# Altium schematic sheet RF.SchDoc
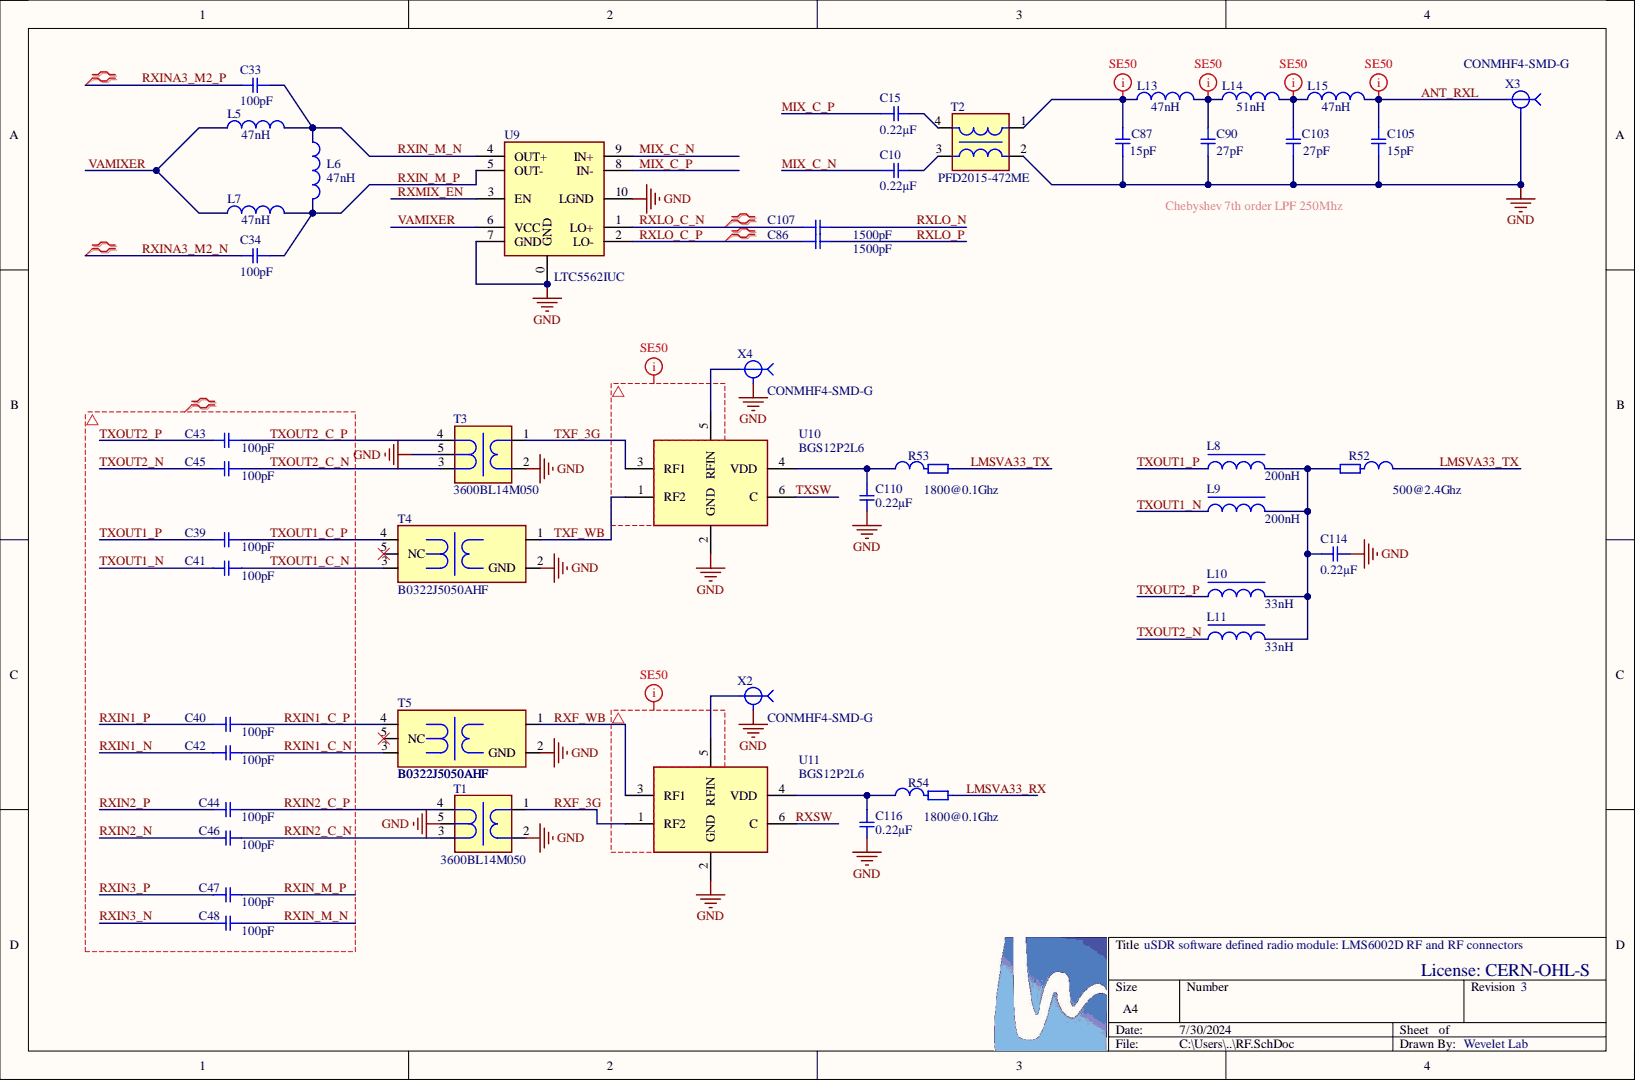
[diagram p0: RF.SchDoc]

## Parts
C10  value 0.22µF  [SMD-0201C]  (hidden comment: GRM033C80J224ME90D)
C15  value 0.22µF  [SMD-0201C]  (hidden comment: GRM033C80J224ME90D)
C33  value 100pF  [SMD-0201C]  (hidden comment: GRM0335C1H101JA01D)
C34  value 100pF  [SMD-0201C]  (hidden comment: GRM0335C1H101JA01D)
C39  value 100pF  [SMD-0201C]  (hidden comment: GRM0335C1H101JA01D)
C40  value 100pF  [SMD-0201C]  (hidden comment: GRM0335C1H101JA01D)
C41  value 100pF  [SMD-0201C]  (hidden comment: GRM0335C1H101JA01D)
C42  value 100pF  [SMD-0201C]  (hidden comment: GRM0335C1H101JA01D)
C43  value 100pF  [SMD-0201C]  (hidden comment: GRM0335C1H101JA01D)
C44  value 100pF  [SMD-0201C]  (hidden comment: GRM0335C1H101JA01D)
C45  value 100pF  [SMD-0201C]  (hidden comment: GRM0335C1H101JA01D)
C46  value 100pF  [SMD-0201C]  (hidden comment: GRM0335C1H101JA01D)
C47  value 100pF  [SMD-0201C]  (hidden comment: GRM0335C1H101JA01D)
C48  value 100pF  [SMD-0201C]  (hidden comment: GRM0335C1H101JA01D)
C86  value 1500pF  [SMD-0201C]  (hidden comment: GRM033R71E152KA01D)
C87  value 15pF  [SMD-0201C]  (hidden comment: GRM0335C1H150FA01D)
C90  value 27pF  [SMD-0201C]  (hidden comment: GRM0335C1H270FA01D)
C103  value 27pF  [SMD-0201C]  (hidden comment: GRM0335C1H270FA01D)
C105  value 15pF  [SMD-0201C]  (hidden comment: GRM0335C1H150FA01D)
C107  value 1500pF  [SMD-0201C]  (hidden comment: GRM033R71E152KA01D)
C110  value 0.22µF  [SMD-0201C]  (hidden comment: GRM033C80J224ME90D)
C114  value 0.22µF  [SMD-0201C]  (hidden comment: GRM033C80J224ME90D)
C116  value 0.22µF  [SMD-0201C]  (hidden comment: GRM033C80J224ME90D)
L5  value 47nH  [SMD-0201-RES]  (hidden comment: MLG0603P47NHT000)
L6  value 47nH  [SMD-0201-RES]  (hidden comment: MLG0603P47NHT000)
L7  value 47nH  [SMD-0201-RES]  (hidden comment: MLG0603P47NHT000)
L8  value 200nH  [0201AF]  (hidden comment: 0201AF-201XKR)
L9  value 200nH  [0201AF]  (hidden comment: 0201AF-201XKR)
L10  value 33nH  [0201AF]  (hidden comment: 0201AF-330XKR)
L11  value 33nH  [0201AF]  (hidden comment: 0201AF-330XKR)
L13  value 47nH  [SMD-0201-RES]  (hidden comment: MLG0603P47NHT000)
L14  value 51nH  [SMD-0201-RES]  (hidden comment: MLG0603P51NHT000)
L15  value 47nH  [SMD-0201-RES]  (hidden comment: MLG0603P47NHT000)
R52  value 500@2.4Ghz  [SMD-0201-RES]  (hidden comment: BLF03RD501GNE)
R53  value 1800@0.1Ghz  [SMD-0201-RES]  (hidden comment: BLM03HD182FN1)
R54  value 1800@0.1Ghz  [SMD-0201-RES]  (hidden comment: BLM03HD182FN1)
T1  3600BL14M050  [Balun 1.6x0.8x0.6mm]
T2  PFD2015-472ME  [PFD2015]
T3  3600BL14M050  [Balun 1.6x0.8x0.6mm]
T4  B0322J5050AHF  [BALUN 2.04x1.39x0.71]
T5  B0322J5050AHF  [BALUN 2.04x1.39x0.71]
U9  LTC5562IUC  [UC10(2x2)]
U10  BGS12P2L6  [TSLP-6-4]
U11  BGS12P2L6  [TSLP-6-4]
X2  CONMHF4-SMD-G  [MHF4]
X3  CONMHF4-SMD-G  [MHF4]
X4  CONMHF4-SMD-G  [MHF4]

## Nets
GND: C87.1 C90.1 C103.1 C105.1 C110.2 C114.1 C116.2 T1.2 T1.5 T2.2 T3.2 T3.5 T4.2(GND) T5.2(GND) U9.0(GND) U9.7(GND) U9.10(LGND) U10.2(GND) U11.2(GND) X2.2 X3.2 X4.2
ANT_RXL: C105.2 L15.2 X3.1
LMSVA33_RX: R54.2
LMSVA33_TX: R52.1 R53.2
MIX_C_N: C10.1 U9.9(IN+)
MIX_C_P: C15.1 U9.8(IN-)
RXF_3G: T1.1 U11.1(RF2)
RXF_WB: T5.1 U11.3(RF1)
RXIN1_C_N: C42.2 T5.3
RXIN1_C_P: C40.2 T5.4
RXIN1_N: C42.1
RXIN1_P: C40.1
RXIN2_C_N: C46.2 T1.3
RXIN2_C_P: C44.2 T1.4
RXIN2_N: C46.1
RXIN2_P: C44.1
RXIN3_N: C48.1
RXIN3_P: C47.1
RXIN_M_N: C33.1 C48.2 L5.1 L6.2 U9.4(OUT+)
RXIN_M_P: C34.1 C47.2 L6.1 L7.1 U9.5(OUT-)
RXINA3_M2_N: C34.2
RXINA3_M2_P: C33.2
RXLO_C_N: C107.1 U9.1(LO+)
RXLO_C_P: C86.1 U9.2(LO-)
RXLO_N: C107.2
RXLO_P: C86.2
RXMIX_EN: U9.3(EN)
RXSW: U11.6(C)
TXF_3G: T3.1 U10.3(RF1)
TXF_WB: T4.1 U10.1(RF2)
TXOUT1_C_N: C41.1 T4.3
TXOUT1_C_P: C39.1 T4.4
TXOUT1_N: C41.2 L9.2
TXOUT1_P: C39.2 L8.2
TXOUT2_C_N: C45.1 T3.3
TXOUT2_C_P: C43.1 T3.4
TXOUT2_N: C45.2 L11.2
TXOUT2_P: C43.2 L10.2
TXSW: U10.6(C)
VAMIXER: L5.2 L7.2 U9.6(VCC)
NetC10_2: C10.2 T2.3
NetC15_2: C15.2 T2.4
NetC87_2: C87.2 L13.1 T2.1
NetC90_2: C90.2 L13.2 L14.1
NetC103_2: C103.2 L14.2 L15.1
NetC110_1: C110.1 R53.1 U10.4(VDD)
NetC114_2: C114.2 L8.1 L9.1 L10.1 L11.1 R52.2
NetC116_1: C116.1 R54.1 U11.4(VDD)
NetU10_5: U10.5(RFIN) X4.1
NetU11_5: U11.5(RFIN) X2.1

Not connected: T4 5(NC); T5 5(NC)

## Library data of the parts
C10 C15 C110 C114 C116 = GRM033C80J224ME90D: CAP CER 0.22UF 6.3V X6S 0201; Manufacturer: Murata Electronics North America; @ qty: 0; Minimum Quantity: 1; Tolerance: ±20%; Unit Price (USD): 0.1; Applications: General Purpose; Component Kind: Standard; Datasheets: http://www.murata.com/~/media/webrenewal/support/library/catalog/products/capacitor/mlcc/c02e.pdf; Digi-Key Part Number: 490-9982-1-ND; Factory Stock: 0; Failure Rate: Surface Mount, MLCC; Features: -; Height - Seated (Max): 0.013" (0.33mm); Image: //media.digikey.com/Renders/Murata%20Renders/ZRB-SERIES-0402.jpg; Lead Spacing: -; Mounting Type: 0201 (0603 Metric); Operating Temperature: -55°C ~ 105°C; Package / Case: 0.024" L x 0.012" W (0.60mm x 0.30mm); Packaging: Cut Tape (CT); Part Number: GRM033C80J224ME90D; Part Status: Active; Quantity Available: 381529; Ratings: -; Series: GRM; Size / Dimension: -; Temperature Coefficient: X6S; Thickness (Max): -; Voltage: 6.3V; Library Name: USDR.IntLib
C33 C34 C39-C48 = GRM0335C1H101JA01D: CAP CER 100PF 50V NP0 0201; Manufacturer: Murata Electronics North America; @ qty: 0; Minimum Quantity: 1; Temperature Coefficient: C0G, NP0; Tolerance: ±5%; Unit Price (USD): 0.1; Applications: General Purpose; Component Kind: Standard; Datasheets: http://www.murata.com/~/media/webrenewal/support/library/catalog/products/capacitor/mlcc/c02e.pdf; Digi-Key Part Number: 490-6113-1-ND; Factory Stock: 0; Failure Rate: Surface Mount, MLCC; Features: -; Height - Seated (Max): -; Image: //media.digikey.com/Renders/Murata%20Renders/ZRB-SERIES-0402.jpg; Mounting Type: 0201 (0603 Metric); Operating Temperature: -55°C ~ 125°C; Package / Case: 0.024" L x 0.012" W (0.60mm x 0.30mm); Packaging: Cut Tape (CT); Part Number: GRM0335C1H101JA01D; Part Status: Active; Quantity Available: 19110; Ratings: -; Series: GRM; Size / Dimension: -; Thickness (Max): -; Voltage: 50V; Library Name: USDR.IntLib
C86 C107 = GRM033R71E152KA01D: CAP CER 1500PF 25V X7R 0201; Manufacturer: Murata Electronics North America; @ qty: 0; Minimum Quantity: 1; Tolerance: ±10%; Unit Price (USD): 0.1; Applications: General Purpose; Component Kind: Standard; Datasheets: http://www.murata.com/~/media/webrenewal/support/library/catalog/products/capacitor/mlcc/c02e.pdf; Digi-Key Part Number: 490-3185-1-ND; Factory Stock: 0; Failure Rate: Surface Mount, MLCC; Features: -; Height - Seated (Max): 0.013" (0.33mm); Image: //media.digikey.com/Renders/Murata%20Renders/ZRB-SERIES-0402.jpg; Lead Spacing: -; Mounting Type: 0201 (0603 Metric); Operating Temperature: -55°C ~ 125°C; Package / Case: 0.024" L x 0.012" W (0.60mm x 0.30mm); Packaging: Cut Tape (CT); Part Number: GRM033R71E152KA01D; Part Status: Active; Quantity Available: 60053; Ratings: -; Series: GRM; Size / Dimension: -; Temperature Coefficient: X7R; Thickness (Max): -; Voltage: 25V; Library Name: USDR.IntLib
C87 C105 = GRM0335C1H150FA01D: CAP CER 15PF 50V NP0 0201; Manufacturer: Murata Electronics North America; @ qty: 0; Minimum Quantity: 1; Temperature Coefficient: C0G, NP0; Tolerance: ±1%; Unit Price (USD): 0.1; Applications: General Purpose; Component Kind: Standard; Datasheets: http://www.murata.com/~/media/webrenewal/support/library/catalog/products/capacitor/mlcc/c02e.pdf; Digi-Key Part Number: 490-GRM0335C1H150FA01DTR-ND; Factory Stock: 0; Failure Rate: Surface Mount, MLCC; Features: -; Height - Seated (Max): -; Image: //media.digikey.com/Renders/Murata%20Renders/ZRB-SERIES-0402.jpg; Mounting Type: 0201 (0603 Metric); Operating Temperature: -55°C ~ 125°C; Package / Case: 0.024" L x 0.012" W (0.60mm x 0.30mm); Packaging: Cut Tape (CT); Part Number: GRM0335C1H150FA01D; Part Status: Active; Quantity Available: 29005; Ratings: -; Series: GRM; Size / Dimension: -; Thickness (Max): -; Voltage: 50V; Library Name: USDR.IntLib
C90 C103 = GRM0335C1H270FA01D: CAP CER 27PF 50V NP0 0201; Manufacturer: Murata Electronics North America; @ qty: 0; Minimum Quantity: 1; Temperature Coefficient: C0G, NP0; Tolerance: ±1%; Unit Price (USD): 0.1; Applications: General Purpose; Component Kind: Standard; Datasheets: http://www.murata.com/~/media/webrenewal/support/library/catalog/products/capacitor/mlcc/c02e.pdf; Digi-Key Part Number: 490-GRM0335C1H270FA01DTR-ND; Factory Stock: 0; Failure Rate: Surface Mount, MLCC; Features: -; Height - Seated (Max): -; Image: //media.digikey.com/Renders/Murata%20Renders/ZRB-SERIES-0402.jpg; Mounting Type: 0201 (0603 Metric); Operating Temperature: -55°C ~ 125°C; Package / Case: 0.024" L x 0.012" W (0.60mm x 0.30mm); Packaging: Cut Tape (CT); Part Number: GRM0335C1H270FA01D; Part Status: Active; Quantity Available: 29005; Ratings: -; Series: GRM; Size / Dimension: -; Thickness (Max): -; Voltage: 50V; Library Name: USDR.IntLib
L5-L7 L13 L15 = MLG0603P47NHT000: IND 47nH 0201; Manufacturer: TDK; Tolerance: ±3%; Component Kind: Standard; Link: https://product.tdk.com/system/files/dam/doc/product/inductor/inductor/smd/catalog/inductor_commercial_high-frequency_mlg0603p_en.pdf; Name: MLG0603P47NHT000; Library Name: USDR.IntLib
L8 L9 = 0201AF-201XKR: RF Choke 200nH 110mA 1.3Ohm DCR; Manufacturer: Coilcraft; Component Kind: Standard; Link: https://www.coilcraft.com/getmedia/29a2c174-dfd1-4d85-9887-6563ebac31ff/0201af.pdf; Name: 0201AF-201XKR; Library Name: USDR.IntLib
L10 L11 = 0201AF-330XKR: RF Choke 33nH 340mA 0.15Ohm DCR; Manufacturer: Coilcraft; Component Kind: Standard; Link: https://www.coilcraft.com/getmedia/29a2c174-dfd1-4d85-9887-6563ebac31ff/0201af.pdf; Name: 0201AF-330XKR; Library Name: USDR.IntLib
L14 = MLG0603P51NHT000: IND 51nH 0201; Manufacturer: TDK; Tolerance: ±3%; Component Kind: Standard; Link: https://product.tdk.com/system/files/dam/doc/product/inductor/inductor/smd/catalog/inductor_commercial_high-frequency_mlg0603p_en.pdf; Name: MLG0603P51NHT000; Library Name: USDR.IntLib
R52 = BLF03RD501GNE: BEAD 0.36Ohm/500mA 500@2.4Ghz; Manufacturer: Murata Electronics North America; Tolerance: ±40%; Component Kind: Standard; Link: https://product.tdk.com/system/files/dam/doc/product/inductor/inductor/smd/catalog/inductor_commercial_high-frequency_mlg0603p_en.pdf; Name: BLF03RD501GNE; Library Name: USDR.IntLib
R53 R54 = BLM03HD182FN1: BEAD 3.8Ohm/100mA 1800@0.1Ghz/3000@1.0Ghz; Manufacturer: TDKMurata Electronics North America; Tolerance: ±3%; Component Kind: Standard; Link: https://product.tdk.com/system/files/dam/doc/product/inductor/inductor/smd/catalog/inductor_commercial_high-frequency_mlg0603p_en.pdf; Name: BLM03HD182FN1; Library Name: USDR.IntLib
T1 T3 = 3600BL14M050: Balun bal-unbal; Manufacturer: Johanson Technology, Inc.; Name: Balun 50/50 3-4 GHz; Component Kind: Standard; Link: https://octopart.com/3600bl14m050t-johanson-11760610; Value: 3600BL14M050; Library Name: USDR.IntLib
T2 = PFD2015-472ME: Balun bal-unbal; Manufacturer: Coilcarft; Name: Balun wirewound 50/50, 0.04-1 GHz; Component Kind: Standard; Link: https://www.coilcraft.com/getmedia/b3a060ea-aafd-4a37-945a-35c311be2ff5/pfd2015_wideband.pdf; Value: PFD2015-472ME; Library Name: USDR.IntLib
T4 T5 = B0322J5050AHF: Balun bal-unbal; Manufacturer: Anaren; Name: Balun 50/50 400-2300 Mhz; Component Kind: Standard; Link: https://octopart.com/search?q=B0322J5050AHF&currency=USD&specs=0; Value: B0322J5050AHF; Library Name: USDR.IntLib
U9 = LTC5562: IC; Manufacturer: Analog; Misc: Mixer; Swap: No; Component Kind: Standard; ComponentLink1Description: Link; ComponentLink1URL: https://octopart.com/search?q=LTC5562IUC%23TRMPBF&currency=USD&specs=0; Name: LTC5562IUC; Library Name: USDR.IntLib
U10 U11 = BGS12P2L6: IC RF SPDT Switch; Manufacturer: Infineon; Misc: SPDT 0.05-6 GHz RF Switch; U/P/TKE: Vcc 1.65-3.4V/Vcont 1.35-Vcc; Component Kind: Standard; Link: https://www.infineon.com/dgdl/Infineon-BGS12P2L6-DataSheet-v02_00-EN.pdf?fileId=5546d4626cb27db2016d4487d53603ce; Name: BGS12P2L6; Library Name: USDR.IntLib
X2-X4 = CONMHF4-SMD-G: Connector (I-PEX MHF4); Alternate Manufacturer: Linx Technologies; Manufacturer: TE Connectivity / Linx Technologies; Alternate Name: RECE.20449.001E.01; Alternate Link: https://linxtechnologies.com/wp/wp-content/uploads/conmhf4-smd-g-t-ds.pdf; Component Kind: Standard; Link: https://www.taoglas.com/datasheets/RECE.20449.001E.01.pdf; Name: CONMHF4-SMD-G; Value: MHF4; Library Name: USDR.IntLib
